# Altium schematic sheet 电源.SchDoc
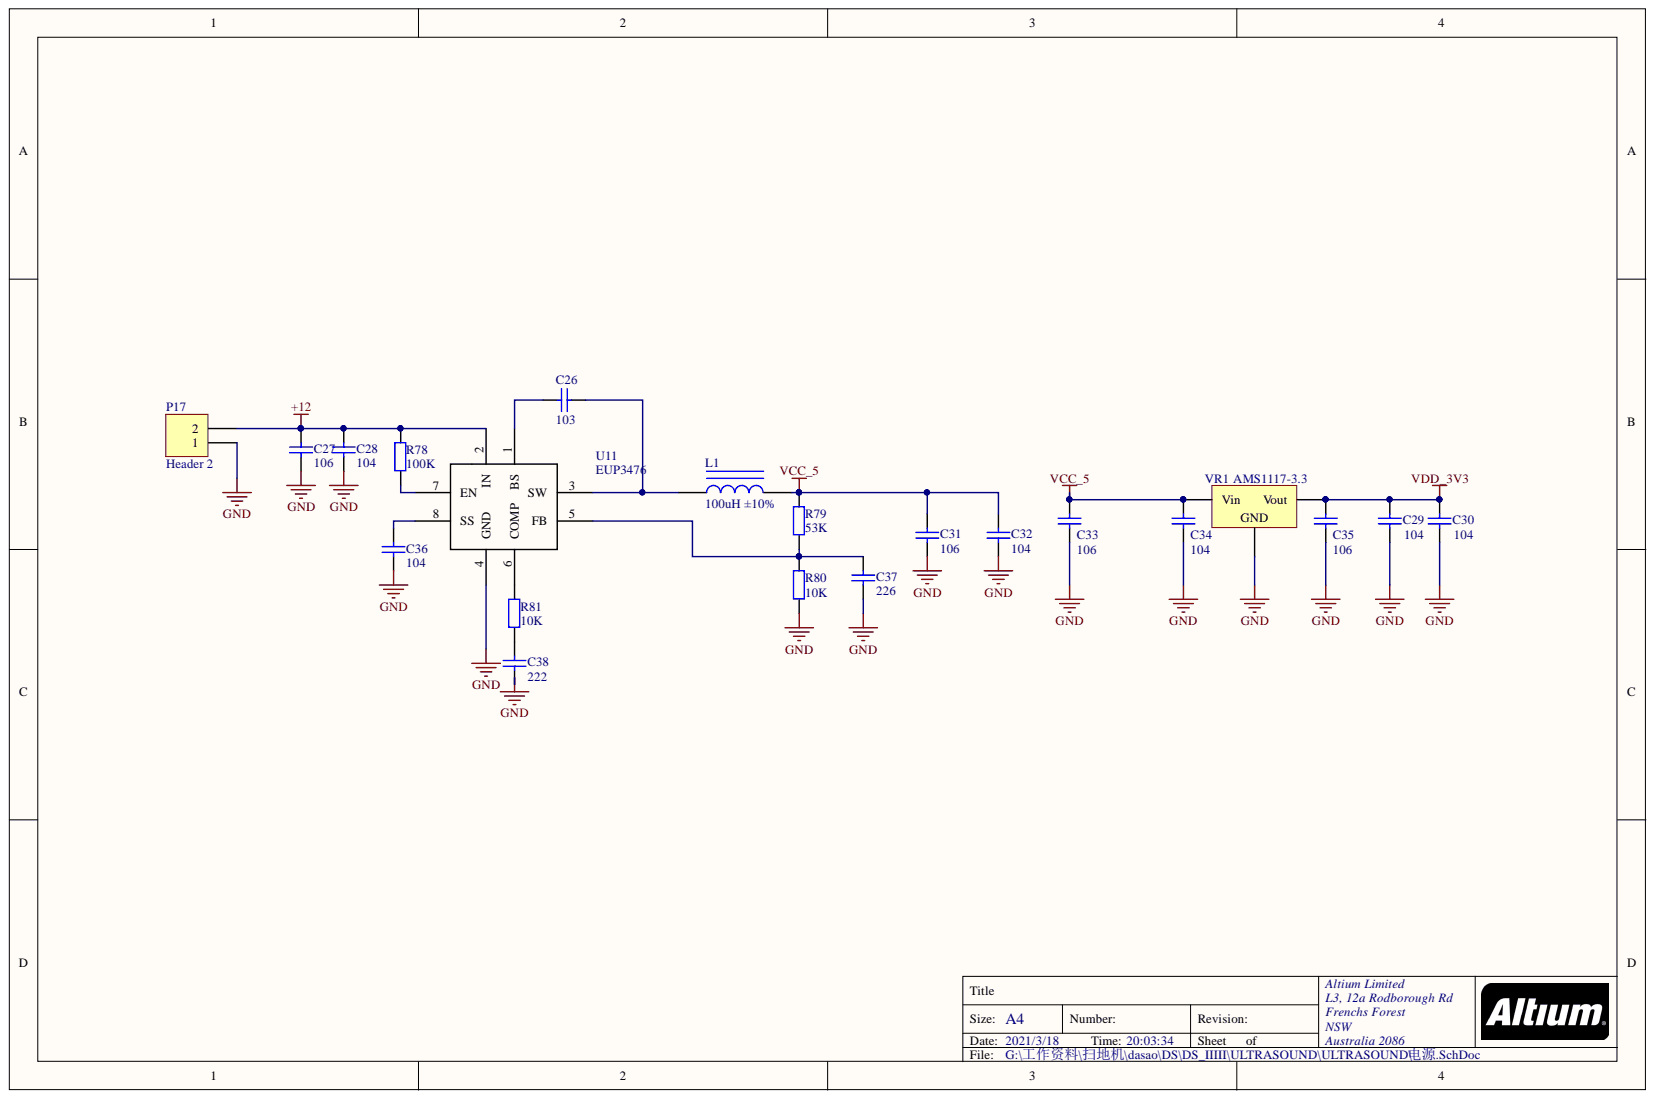
[diagram p0: 电源.SchDoc]

## Parts
C26  103  [0603_C]
C27  106  [1206_C]
C28  104  [0603_C]
C29  104  [0603_C]
C30  104  [0603_C]
C31  106  [1206_C]
C32  104  [0603_C]
C33  106  [1206_C]
C34  104  [0603_C]
C35  106  [1206_C]
C36  104  [0603_C]
C37  226  [0603_C]
C38  222  [0603_C]
L1  100uH ±10%  [IN]
P17  Header 2  [CON1.5_2pin]
R78  100K  [0603_R]
R79  53K  [0603_R]
R80  10K  [0603_R]
R81  10K  [0603_R]
U11  EUP3476  [SOP-8_150mil]
VR1  AMS1117-3.3  [SOT-223]

## Nets
+12: C27.2 C28.1 P17.2 R78.2 U11.2(IN)
GND: C27.1 C28.2 C29.2 C30.2 C31.1 C32.2 C33.2 C34.2 C35.2 C36.2 C37.1 C38.2 P17.1 R80.1 U11.4(GND) VR1.1
VCC_5: C31.2 C32.1 C33.1 C34.1 L1.2 R79.2 VR1.3
VDD_3V3: C29.1 C30.1 C35.1 VR1.2
NetC26_1: C26.1 U11.1(BS)
NetC26_2: C26.2 L1.1 U11.3(SW)
NetC36_1: C36.1 U11.8(SS)
NetC37_2: C37.2 R79.1 R80.2 U11.5(FB)
NetC38_1: C38.1 R81.1
NetR78_1: R78.1 U11.7(EN)
NetR81_2: R81.2 U11.6(COMP)

## Library data of the parts
C26 = 0603 10nF (103) 10% 50V: 贴片电容; Component Kind: Standard; ComponentLink1Description: 供应商链接; ComponentLink1URL: http://www.szlcsc.com/product/details_58143.html; Library Name: Miscellaneous Devices LC.IntLib; Package: 0603; Supplier: LC; SuppliersPartNumber: C57112
C27 C31 = 0603 10uF (106) 10% 10V: 贴片电容; Component Kind: Standard; ComponentLink1Description: 供应商链接; ComponentLink1URL: http://www.szlcsc.com/product/details_20411.html; Library Name: Miscellaneous Devices LC.IntLib; Package: 0603; Supplier: LC; SuppliersPartNumber: C19702
C28 C32 C36 = 0603 100nF (104) 10% 50V: 贴片电容; Component Kind: Standard; ComponentLink1Description: 供应商链接; ComponentLink1URL: http://www.szlcsc.com/product/details_15331.html; Library Name: Miscellaneous Devices LC.IntLib; Package: 0603; Supplier: LC; SuppliersPartNumber: C14663
C29 C30 C33-C35 = 0603 1uF (105) 10% 50V: 贴片电容; Component Kind: Standard; ComponentLink1Description: 供应商链接; ComponentLink1URL: http://www.szlcsc.com/product/details_16531.html; Library Name: Miscellaneous Devices LC.IntLib; Supplier: LC; SuppliersPartNumber: C15849
  C29 C30 C34: Package: 0603
  C33 C35: Package: 1206
C37 = 0603 22uF (226) 20% 6.3V: 贴片电容; Component Kind: Standard; ComponentLink1Description: 供应商链接; ComponentLink1URL: http://www.szlcsc.com/product/details_60514.html; Library Name: Miscellaneous Devices LC.IntLib; Package: 0603; Supplier: LC; SuppliersPartNumber: C59461
C38 = 0603 2.2nF (222) 10% 50V: 贴片电容; Component Kind: Standard; ComponentLink1Description: 供应商链接; ComponentLink1URL: http://www.szlcsc.com/product/details_1956.html; Library Name: Miscellaneous Devices LC.IntLib; Package: 0603; Supplier: LC; SuppliersPartNumber: C1604
L1 = 0805 100uH 10%: 贴片电感; Component Kind: Standard; ComponentLink1Description: 供应商链接; ComponentLink1URL: http://www.szlcsc.com/product/details_69137.html; Library Name: Miscellaneous Devices LC.IntLib; Package: 0805; Supplier: LC; SuppliersPartNumber: C68035
P17 = Header 2: Header, 2-Pin; Component Kind: Standard; LatestRevisionDate: 17-Jul-2002; LatestRevisionNote: Re-released for DXP Platform.; Library Name: Miscellaneous Connectors.IntLib; Signal Integrity: Connector; Publisher: Altium Limited
R78 = 0603 100KΩ (1003) 1%: 贴片电阻; Component Kind: Standard; ComponentLink1Description: 供应商链接; ComponentLink1URL: http://www.szlcsc.com/product/details_26546.html; Library Name: Miscellaneous Devices LC.IntLib; Package: 0603; Supplier: LC; SuppliersPartNumber: C25803
R79 = 0603 33KΩ (3302) 1%: 贴片电阻; Component Kind: Standard; ComponentLink1Description: 供应商链接; ComponentLink1URL: http://www.szlcsc.com/product/details_4623.html; Library Name: Miscellaneous Devices LC.IntLib; Package: 0603; Supplier: LC; SuppliersPartNumber: C4216
R80 R81 = 0603 10KΩ (1002) 1%: 贴片电阻; Component Kind: Standard; ComponentLink1Description: 供应商链接; ComponentLink1URL: http://www.szlcsc.com/product/details_26547.html; Library Name: Miscellaneous Devices LC.IntLib; Package: 0603; Supplier: LC; SuppliersPartNumber: C25804
VR1 = SOT-223 AMS1117-3.3: 低压差线性稳压(LDO); Component Kind: Standard; ComponentLink1Description: 供应商链接; ComponentLink1URL: http://www.szlcsc.com/product/details_6652.html; Library Name: Miscellaneous Devices LC.IntLib; Package: SOT-223; Supplier: LC; SuppliersPartNumber: C6186
U11 = EUP3476: Component Kind: Standard; Library Name: Schlib1.SchLib
